# Altium schematic sheet DC-link.SchDoc
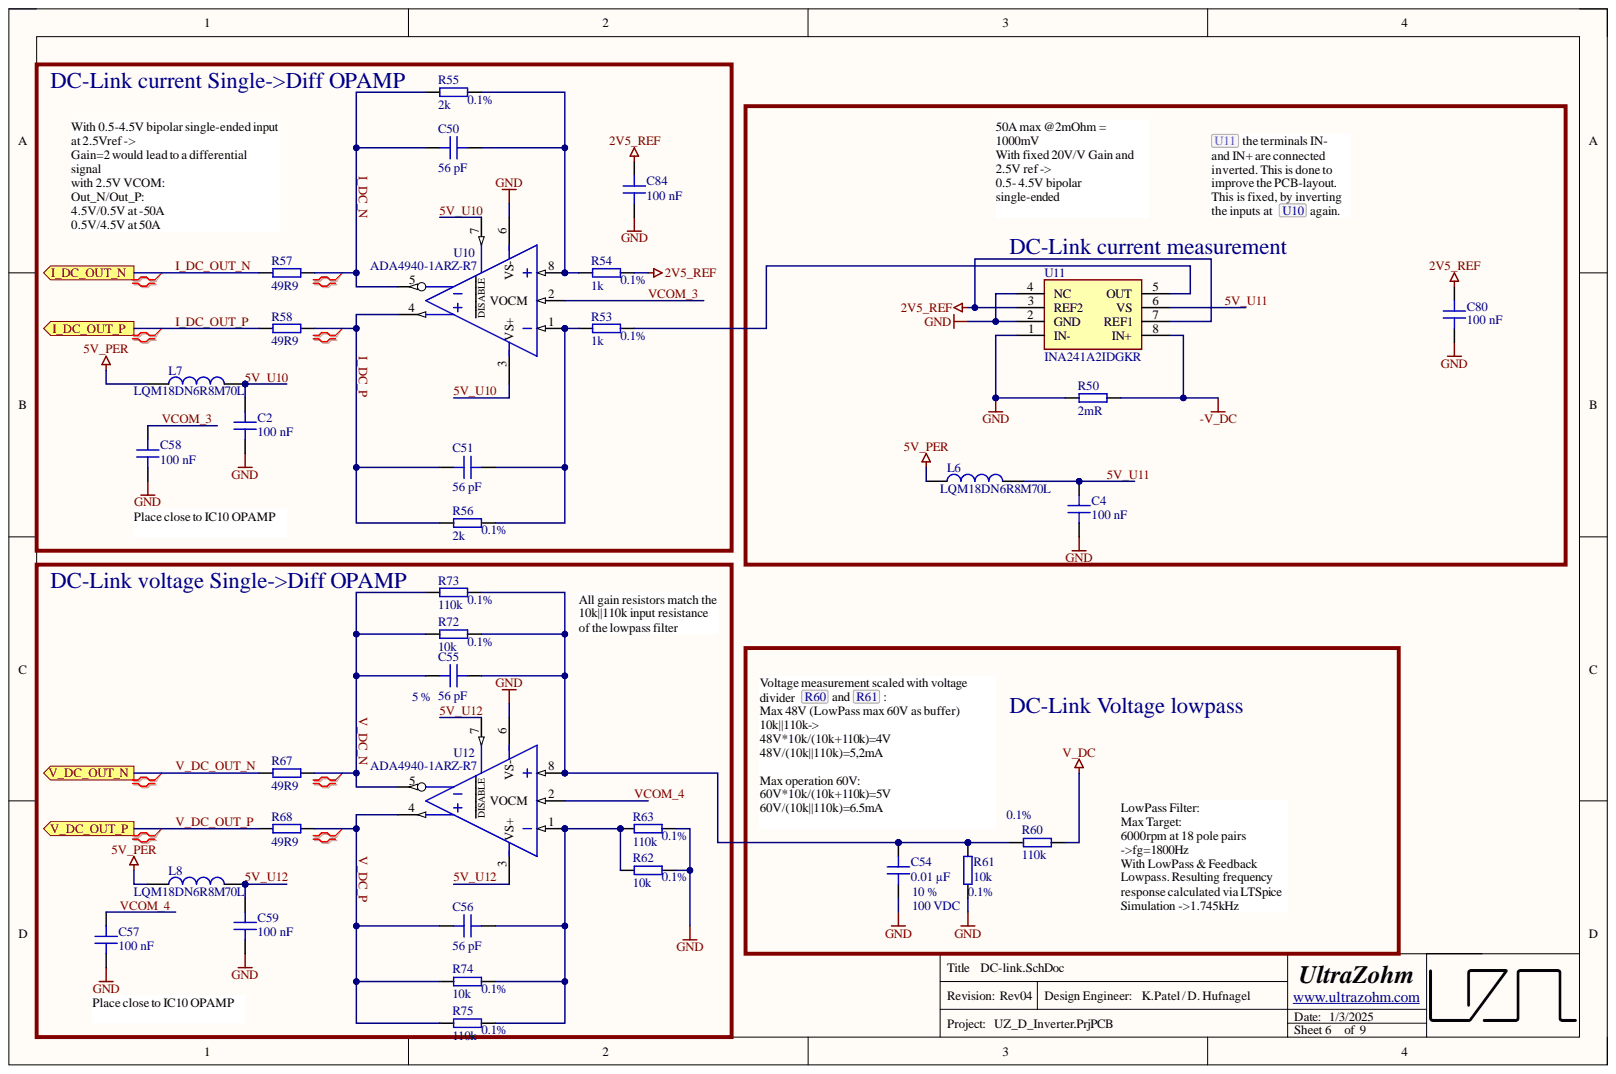
[diagram p0: DC-link.SchDoc]

## Parts
C2  100 nF  [C_0603]
C4  100 nF  [C_0603]
C50  56 pF  [C_0603]
C51  56 pF  [C_0603]
C54  0.01 µF  Tolerance: 10 %  [C_0805]
C55  56 pF  Tolerance: 5 %  [C_0603]
C56  56 pF  [C_0603]
C57  100 nF  [C_0603]
C58  100 nF  [C_0603]
C59  100 nF  [C_0603]
C80  100 nF  [C_0603]
C84  100 nF  [C_0603]
L6  LQM18DN6R8M70L  [INDC1608X95N]
L7  LQM18DN6R8M70L  [INDC1608X95N]
L8  LQM18DN6R8M70L  [INDC1608X95N]
R50  2mR  [3921]
R53  1k  Tolerance: 0.1%  [RES 0603_1608]
R54  1k  Tolerance: 0.1%  [RES 0603_1608]
R55  2k  Tolerance: 0.1%  [RES 0603_1608]
R56  2k  Tolerance: 0.1%  [RES 0603_1608]
R57  49R9  [RES 0603_1608]
R58  49R9  [RES 0603_1608]
R60  110k  Tolerance: 0.1%  [RES 0603_1608]
R61  10k  Tolerance: 0.1%  [RES 0603_1608]
R62  10k  Tolerance: 0.1%  [RES 0603_1608]
R63  110k  Tolerance: 0.1%  [RES 0603_1608]
R67  49R9  [RES 0603_1608]
R68  49R9  [RES 0603_1608]
R72  10k  Tolerance: 0.1%  [RES 0603_1608]
R73  110k  Tolerance: 0.1%  [RES 0603_1608]
R74  10k  Tolerance: 0.1%  [RES 0603_1608]
R75  110k  Tolerance: 0.1%  [RES 0603_1608]
U10  ADA4940-1ARZ-R7  [SOT127P600X175-8N]
U11  INA241A2IDGKR  [SOP65P490X110-8N]
U12  ADA4940-1ARZ-R7  [SOT127P600X175-8N]

## Ports
I_DC_OUT_N, I_DC_OUT_P, V_DC_OUT_N, V_DC_OUT_P

## Nets
2V5_REF: C80.2 C84.2 R54.2 U11.3(REF2) U11.7(REF1)
5V_PER: L6.1 L7.1 L8.1
-V_DC: R50.2 U11.8(IN+)
GND: C2.1 C4.1 C54.1 C57.1 C58.1 C59.1 C80.1 C84.1 R50.1 R61.1 R62.1 R63.2 U10.6(VS-) U11.1(IN-) U11.2(GND) U11.4(NC) U12.6(VS-)
V_DC: R60.2
5V_U10: C2.2 L7.2 U10.3(VS+) U10.7
5V_U11: C4.2 L6.2 U11.6(VS)
5V_U12: C59.2 L8.2 U12.3(VS+) U12.7
I_DC_N: C50.1 R55.1 R57.2 U10.5
I_DC_OUT_N: R57.1 ; port I_DC_OUT_N
I_DC_OUT_P: R58.1 ; port I_DC_OUT_P
I_DC_P: C51.2 R56.2 R58.2 U10.4
V_DC_N: C55.1 R67.2 R72.2 R73.1 U12.5
V_DC_OUT_N: R67.1 ; port V_DC_OUT_N
V_DC_OUT_P: R68.1 ; port V_DC_OUT_P
V_DC_P: C56.2 R68.2 R74.2 R75.1 U12.4
VCOM_3: C58.2 U10.2(VOCM)
VCOM_4: C57.2 U12.2(VOCM)
NetC50_2: C50.2 R54.1 R55.2 U10.8
NetC51_1: C51.1 R53.1 R56.1 U10.1
NetC54_2: C54.2 C55.2 R60.1 R61.2 R72.1 R73.2 U12.8
NetC56_1: C56.1 R62.2 R63.1 R74.1 R75.2 U12.1
NetR53_2: R53.2 U11.5(OUT)

## Library data of the parts
C2 C4 C57-C59 C80 C84 = C1608X7S2A104KT000N: 100V 100nF X7S ±10% 0603 Multilayer Ceramic Capacitors MLCC - SMD/SMT ROHS; Manufacturer: TDK; Created from: AGeiger; Database Table Name: Capacitors - SMD; Voltage Rating: 100 VDC; Component Kind: Standard; Component Type: Capacitor 0603; ComponentLink1Description: Datasheet; ComponentLink1URL: https://datasheet.lcsc.com/lcsc/2304140030_TDK-C1608X7S2A104KT000N_C343025.pdf; Created On: 20.12.2020 13:54:13; Device Package: 0603; Last Updated: 14.12.2022 12:14:21; LCSC Part Number: C343025; Library Name: user.DbLib; Live Cycle Status: 1; Manufacturer Part Number: C1608X7S2A104KT000N; Mounting Type: SMD; Operating Temperature: -55 to 125°C; PartID: 148; Series: X7R; Stock number: not stored; Supplier 1: LCSC; Supplier Part Number 1: C343025; Tolerance: 10 %; Type: Capacitor; Value: 100 nF
C50 C51 C56 = CL10C560JB8NNNC: Capacitor 0603, 50 VDC, 56 pF, C0G; Manufacturer: Samsung Electro-Mechanics; Created from: DHufnagel; Database Table Name: Capacitors - SMD; Tolerance: 5 %; Voltage Rating: 50 VDC; Component Kind: Standard; Component Type: Capacitor 0603; ComponentLink1Description: Datasheet; ComponentLink1URL: https://www.mouser.de/datasheet/2/585/MLCC-1837944.pdf; Created On: 20.12.2020 13:54:13; Device Package: 0603; Last Updated: 20.12.2020 16:24:16; LCSC Part Number: C39148; Library Name: user.DbLib; Live Cycle Status: 1; Manufacturer Part Number: CL10C560JB8NNNC; Mounting Type: SMD; Operating Temperature: -55 - 125°C; PartID: 92; Series: CL; Stock number: not stored; Supplier 1: Mouser; Supplier 2: LCSC; Supplier Part Number 1: 187-CL10C560JB8NNNC; Supplier Part Number 2: C39148; Type: Capacitor; Value: 56 pF
C54 = HV0805X7R103K101NT: 100V 10nF 0805 Multilayer Ceramic Capacitors MLCC - SMD/SMT ROHS; Manufacturer: AIDE; Created from: KIlhan; Database Table Name: Capacitors - SMD; Voltage Rating: 100 VDC; Component Kind: Standard; Component Type: Capacitor 0805; ComponentLink1Description: Datasheet; ComponentLink1URL: https://datasheet.lcsc.com/lcsc/2103311437_AIDE-HV1210X7R332K202NT_C2691813.pdf; Created On: 05.07.2023 15:42:28; Device Package: 0805; Last Updated: 05.07.2023 15:42:28; LCSC Part Number: C2691724; Library Name: user.DbLib; Live Cycle Status: 1; Manufacturer Part Number: HV0805X7R103K101NT; Mounting Type: SMD; Operating Temperature: -55 - 125°C; PartID: 128; Series: C; Stock number: not stored; Supplier 1: JLCPCB; Supplier Part Number 1: HV0805X7R103K101NT; Type: Capacitor; Value: 0.01 µF
C55 = CL10C560JB8NNNC: Capacitor 0603, 50 VDC, 56 pF, C0G; Manufacturer: Samsung Electro-Mechanics; Created from: DHufnagel; Database Table Name: Capacitors - SMD; Voltage Rating: 50 VDC; Component Kind: Standard; Component Type: Capacitor 0603; ComponentLink1Description: Datasheet; ComponentLink1URL: https://www.mouser.de/datasheet/2/585/MLCC-1837944.pdf; Created On: 20.12.2020 13:54:13; Device Package: 0603; Last Updated: 20.12.2020 16:24:16; LCSC Part Number: C39148; Library Name: user.DbLib; Live Cycle Status: 1; Manufacturer Part Number: CL10C560JB8NNNC; Mounting Type: SMD; Operating Temperature: -55 - 125°C; PartID: 92; Series: CL; Stock number: not stored; Supplier 1: Mouser; Supplier 2: LCSC; Supplier Part Number 1: 187-CL10C560JB8NNNC; Supplier Part Number 2: C39148; Type: Capacitor; Value: 56 pF
L6-L8 = LQM18DN6R8M70L: 6.8µH, 120mA, 0603, 740mOhm, 20%; Manufacturer: Murata; MPN: B82464G4154M; Created from: DHufnagel; Database Table Name: Inductances - SMD; DCR: 320m; MF: EPCOS / TDK; Rated Current: 0.85 A; Type: fixed inductor; Value: 6.8uH; Component Kind: Standard; Component Type: SMD fixed inductor; ComponentLink1Description: Datasheet; ComponentLink1URL: https://www.mouser.de/datasheet/2/281/1/JELF243B_0086-2298241.pdf; Created On: 19.07.2022 15:47:17; DC current: 120mA; Device Package: SMD; Last Updated: 15.06.2023 16:03:16; LCSC Part Number: C1881372; Library Name: user.DbLib; Live Cycle Status: 1; Manufacturer Part Number: LQM18DN6R8M70L; Mounting Type: SMD; Operating Temperature: -40 - 125°C; PartID: 10; Series: LQM18DN_70; Stock number: not stored; Supplier 1: Mouser; Supplier 2: Digi-Key; Supplier Part Number 1: 81-LQM18DN6R8M70L; Supplier Part Number 2: 490-LQM18DN6R8M70LCT-ND
R50 = PU3921FKMP50R002L: Shunt resistor 2mOhm, 5W, 1%; Manufacturer: Vishay; Manufacturer Part Number: WSL39212L000FEA; Created from: DHufnagel; Database Table Name: Resistors - SMD; Component Kind: Standard; Component Type: Shunt SMD resistor; ComponentLink1Description: Datasheet; ComponentLink1URL: https://www.mouser.de/datasheet/2/447/PYu_PU_51_RoHS_L_8-3003083.pdf; Created On: 12.07.2022 07:38:48; Device Package: 3921; Last Updated: 16.06.2023 12:23:12; LCSC Part Number: C844494; Library Name: user.DbLib; Live Cycle Status: 1; Mounting Type: SMD; PartID: 236; Stock number: not stored; Supplier 1: Mouser; Supplier Part Number 1: 71-WSL39212L000FEA; Tolerance: 1%; Type: Shunt; Value: 2mR
R53 R54 = PCF-W0603LF-03-1001-B-P-LT: SMD resistor 0.1%; Manufacturer: IRC / TT Electronics; Created from: MGremer; Database Table Name: Resistors - SMD; Component Kind: Standard; Component Type: SMD resistor; ComponentLink1Description: Datasheet; ComponentLink1URL: https://www.mouser.de/datasheet/2/414/PCF-1528243.pdf; Created On: 14.12.2020 16:17:14; Device Package: 0603; Last Updated: 21.02.2024 15:45:55; LCSC Part Number: C110776; Library Name: user.DbLib; Live Cycle Status: 1; Manufacturer Part Number: PCF-W0603LF-03-1001-B-P-LT; Mounting Type: SMD; PartID: 163; Stock number: not stored; Supplier 1: Mouser; Supplier Part Number 1: 66-PCFW0603LF031001B; Type: Resistor; Value: 1k
R55 R56 = ERJ-PB3B2001V: SMD resistor 0.1%; Manufacturer: Panasonic; Created from: DHufnagel; Database Table Name: Resistors - SMD; Component Kind: Standard; Component Type: SMD resistor; ComponentLink1Description: Datasheet; ComponentLink1URL: https://www.mouser.de/datasheet/2/315/AOA0000C328-1530132.pdf; Created On: 14.12.2020 16:17:14; Device Package: 0603; Last Updated: 02.03.2021 14:07:32; LCSC Part Number: C189729; Library Name: user.DbLib; Live Cycle Status: 1; Manufacturer Part Number: ERJ-PB3B2001V; Mounting Type: SMD; PartID: 215; Stock number: not stored; Supplier 1: Mouser; Supplier 2: LCSC; Supplier Part Number 1: 667-ERJ-PB3B2001V; Supplier Part Number 2: C189729; Type: Resistor; Value: 2k
R57 R58 R67 R68 = RK73H1JTTD49R9F: SMD resistor 1%; Manufacturer: KOA Speer; Created from: DHufnagel; Database Table Name: Resistors - SMD; Component Kind: Standard; Component Type: SMD resistor; ComponentLink1Description: Datasheet; ComponentLink1URL: https://www.mouser.de/datasheet/2/219/RK73H-1825326.pdf; Created On: 14.12.2020 16:17:14; Device Package: 0603; Last Updated: 27.05.2022 13:46:35; LCSC Part Number: C160046; Library Name: user.DbLib; Live Cycle Status: 1; Manufacturer Part Number: RK73H1JTTD49R9F; Mounting Type: SMD; PartID: 214; Stock number: not stored; Supplier 1: Mouser; Supplier 2: LCSC; Supplier Part Number 1: 660-RK73H1JTTD49R9F; Supplier Part Number 2: C160046; Tolerance: 1%; Type: Resistor; Value: 49R9
R60 R63 R73 R75 = RQ73C1J110KBTD: SMD resistor 0.1%, 10ppm; Manufacturer: TE Connectivity / Holsworthy; Created from: DHufnagel; Database Table Name: Resistors - SMD; Component Kind: Standard; Component Type: SMD resistor; ComponentLink1Description: Datasheet; ComponentLink1URL: https://www.mouser.de/datasheet/2/418/5/ENG_DS_1773270_2_B-1533717.pdf; Created On: 14.12.2020 16:17:14; Device Package: 0603; Last Updated: 21.02.2024 16:00:52; LCSC Part Number: C326736; Library Name: user.DbLib; Live Cycle Status: 1; Manufacturer Part Number: RQ73C1J110KBTD; Mounting Type: SMD; PartID: 216; Stock number: not stored; Supplier 1: Mouser; Supplier 2: LCSC; Supplier Part Number 1: 279-RQ73C1J110KBTD; Supplier Part Number 2: C326736; Type: Resistor; Value: 110k
R61 R62 R72 R74 = RQ73C1J10KBTD: SMD resistor 0.1%, 10ppm; Manufacturer: TE Connectivity; Created from: DHufnagel; Database Table Name: Resistors - SMD; Component Kind: Standard; Component Type: SMD resistor; ComponentLink1Description: Datasheet; ComponentLink1URL: https://www.mouser.de/datasheet/2/418/5/ENG_DS_1773270_2_B-1533717.pdf; Created On: 14.12.2020 16:17:14; Device Package: 0603; Last Updated: 21.02.2024 15:51:17; LCSC Part Number: C95204; Library Name: user.DbLib; Live Cycle Status: 1; Manufacturer Part Number: RQ73C1J10KBTD; Mounting Type: SMD; PartID: 231; Stock number: not stored; Supplier 1: Mouser; Supplier 2: LCSC; Supplier Part Number 1: 279-RQ73C1J10KBTD; Supplier Part Number 2: C95204; Type: Resistor; Value: 10k
U10 U12 = ADA4940-1ARZ-R7: Fully Differential Amplifier, Ultralow-Power,Low Distortion, 260-MHz; Manufacturer: Analog Devices; Channels: 1; CMRR: 86 dB; Created from: DHufnagel; Database Table Name: Logic - Operational Amplifiers; GBP: 260 MHz; Component Kind: Standard; Component Type: Differential Amplifier; ComponentLink1Description: Datasheet; ComponentLink1URL: https://www.mouser.de/datasheet/2/609/ada4940_1_4940_2-2955874.pdf; Created On: 18.08.2022 12:53:27; Device Package: SOIC-8; Last Updated: 18.08.2022 13:04:18; LCSC Part Number: C730049; Library Name: user.DbLib; Live Cycle Status: 1; Manufacturer Part Number: ADA4940-1ARZ-R7; Mounting Type: SMD; Operating Temperature: -40 - 105°C; PartID: 14; Series: ADA4940-1; Stock number: not stored; Supplier 1: Mouser; Supplier 2: Digi-Key; Supplier 3: LCSC; Supplier Part Number 1: 584-ADA4940-1ARZ; Supplier Part Number 2: 505-ADA4940-1ARZ-ND; Supplier Part Number 3: C730049; Type: Differential Amplifiers
U11 = INA241A2IDGKR: Current Sense Amplifier, 5-100 V  CMV, 20 V/V, bidirectional; Manufacturer: Texas Instruments; Channels: 1; CMRR: 89dB; Created from: KPatel; Database Table Name: Logic - Operational Amplifiers; GBP: 100MHz; Value: Rail-to-Rail; Component Kind: Standard; Component Type: Current Sense Amplifier; ComponentLink1Description: Datasheet; ComponentLink1URL: https://www.ti.com/lit/ds/symlink/ina241b.pdf?ts=1718088817119&ref_url=https%253A%252F%252Fwww.mouser.de%252F; Created On: 6/28/2024 9:00:46 AM; Device Package: VSSOP-8; Last Updated: 6/28/2024 9:00:46 AM; Library Name: user.DbLib; Live Cycle Status: 1; Manufacturer Part Number: INA241A2IDGKR; Mounting Type: SMD; Operating Temperature: -40 - 125°C; PartID: 30; Series: INA241A; Stock number: not stored; Supplier 1: Mouser; Supplier Part Number 1: 595-INA241A2IDGKR; Type: Current Sense Amplifier
